# Altium schematic sheet Compressor.SchDoc
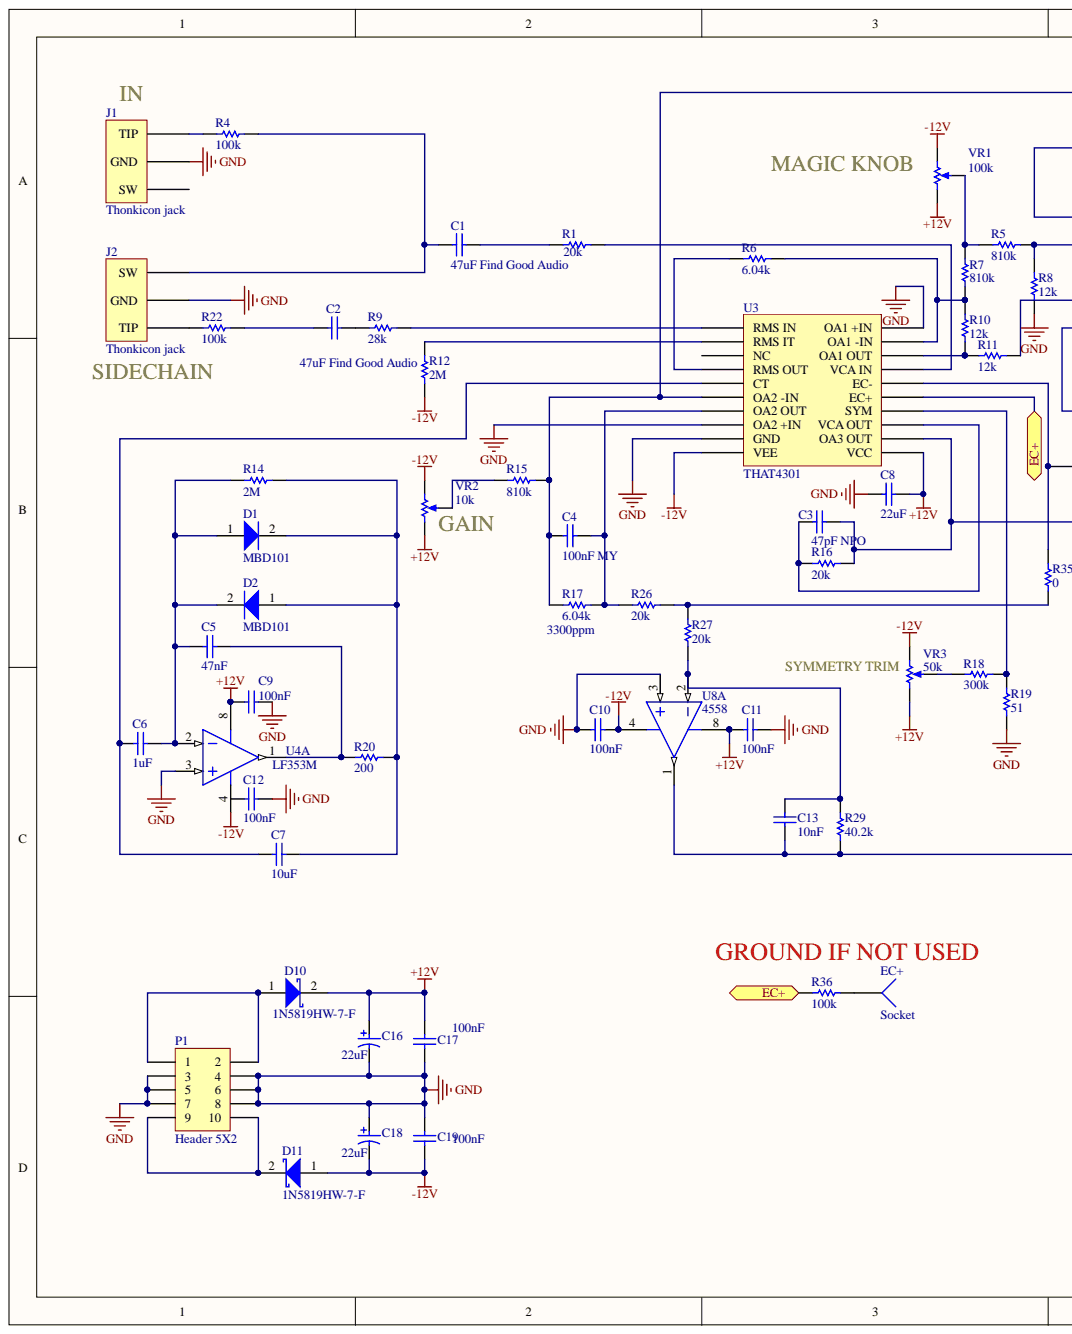
[diagram p0: Compressor.SchDoc, left]
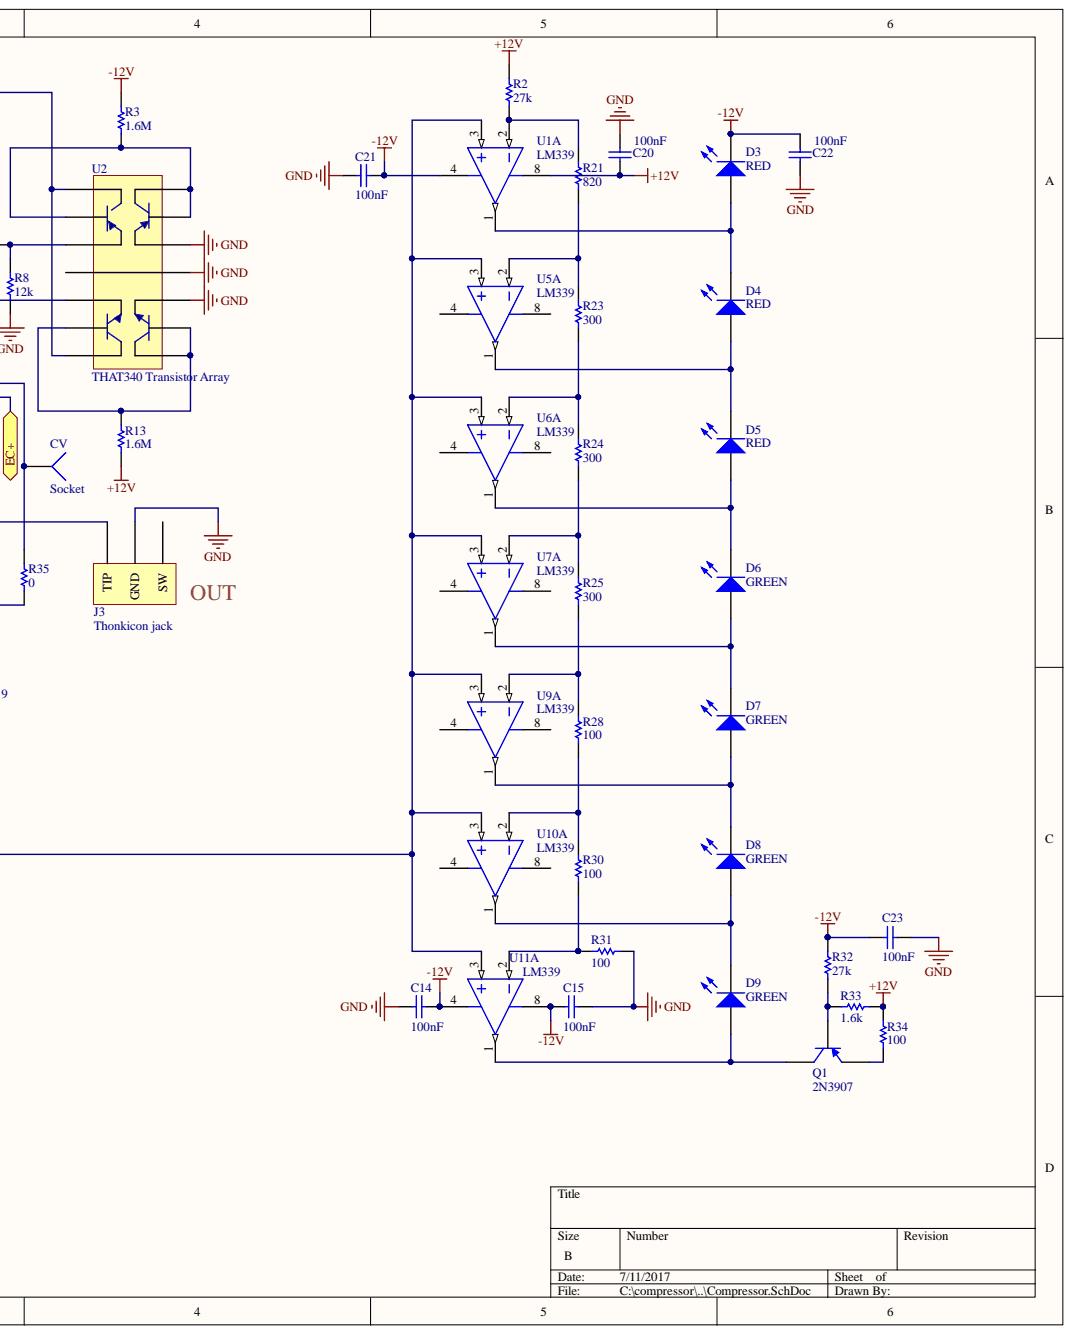
[diagram p1: Compressor.SchDoc, right]

## Parts
C1  47uF Find Good Audio  value 47uF  [CAPC1206(3216)190_N]
C2  47uF Find Good Audio  value 47uF  [CAPC1206(3216)190_N]
C3  47pF NPO  [CAPC1206(3216)190_N]
C4  100nF MY  [CAPC1206(3216)190_N]
C5  47nF  [CAPC1206(3216)190_N]
C6  1uF  [CAPC1206(3216)190_N]
C7  10uF  [CAPC1206(3216)190_N]
C8  22uF  [CAPC1206(3216)190_N]
C9  100nF  [CAPC0603(1608)100_M]
C10  100nF  [CAPC0603(1608)100_M]
C11  100nF  [CAPC0603(1608)100_M]
C12  100nF  [CAPC0603(1608)100_M]
C13  10nF  [CAPC1206(3216)190_N]
C14  100nF  [CAPC0603(1608)100_M]
C15  100nF  [CAPC0603(1608)100_M]
C16  22uF  [NIC-CAPA2-4X5.8_V]
C17  100nF  [CAPC0603(1608)100_M]
C18  22uF  [NIC-CAPA2-4X5.8_V]
C19  100nF  [CAPC0603(1608)100_M]
C20  100nF  [CAPC0603(1608)100_M]
C21  100nF  [CAPC0603(1608)100_M]
C22  100nF  [CAPC0603(1608)100_M]
C23  100nF  [CAPC0603(1608)100_M]
CV  Socket  [PIN1]
D1  MBD101  [ONSC-TO-92-2-182-06]
D2  MBD101  [ONSC-TO-92-2-182-06]
D3  RED  [LED-0]
D4  RED  [LED-0]
D5  RED  [LED-0]
D6  GREEN  [LED-0]
D7  GREEN  [LED-0]
D8  GREEN  [LED-0]
D9  GREEN  [LED-0]
D10  1N5819HW-7-F  [DIOD-SOD-123-2_V]
D11  1N5819HW-7-F  [DIOD-SOD-123-2_V]
EC+  Socket  [PIN1]
J1  Thonkicon jack  [Thonkiconn Pot PJ301M]
J2  Thonkicon jack  [Thonkiconn Pot PJ301M]
J3  Thonkicon jack  [Thonkiconn Pot PJ301M]
P1  Header 5X2  [HDR2X5]
Q1  2N3907  [TO-92A]
R1  20k  [RESC0603(1608)_M]
R2  27k  [RESC0603(1608)_M]
R3  1.6M  [RESC0603(1608)_M]
R4  100k  [RESC0603(1608)_M]
R5  810k  [RESC0603(1608)_M]
R6  6.04k  [RESC0603(1608)_M]
R7  810k  [RESC0603(1608)_M]
R8  12k  [RESC0603(1608)_M]
R9  28k  [RESC0603(1608)_M]
R10  12k  [RESC0603(1608)_M]
R11  12k  [RESC0603(1608)_M]
R12  2M  [RESC0603(1608)_M]
R13  1.6M  [RESC0603(1608)_M]
R14  2M  [RESC0603(1608)_M]
R15  810k  [RESC0603(1608)_M]
R16  20k  [RESC0603(1608)_M]
R17  6.04k  Temp Cpef: 3300ppm  [RESC0603(1608)_M]
R18  300k  [RESC0603(1608)_M]
R19  51  [RESC0603(1608)_M]
R20  200  [RESC0603(1608)_M]
R21  820  [RESC0603(1608)_M]
R22  100k  [RESC0603(1608)_M]
R23  300  [RESC0603(1608)_M]
R24  300  [RESC0603(1608)_M]
R25  300  [RESC0603(1608)_M]
R26  20k  [RESC0603(1608)_M]
R27  20k  [RESC0603(1608)_M]
R28  100  [RESC0603(1608)_M]
R29  40.2k  [RESC0603(1608)_M]
R30  100  [RESC0603(1608)_M]
R31  100  [RESC0603(1608)_M]
R32  27k  [RESC0603(1608)_M]
R33  1.6k  [RESC0603(1608)_M]
R34  100  [RESC0603(1608)_M]
R35  0  [RESC0603(1608)_M]
R36  100k  [RESC0603(1608)_M]
U1  LM339  [D0008A_M]  (part A)
U2  THAT340 Transistor Array
U3  THAT4301
U4  LF353M  [D0008A_M]  (part A)
U5  LM339  [D0008A_M]  (part A)
U6  LM339  [D0008A_M]  (part A)
U7  LM339  [D0008A_M]  (part A)
U8  4558  [D0008A_M]  (part A)
U9  LM339  [D0008A_M]  (part A)
U10  LM339  [D0008A_M]  (part A)
U11  LM339  [D0008A_M]  (part A)
VR1  100k  [SonGueiPot]
VR2  10k  [SonGueiPot]
VR3  50k  [SonGueiPot]

## Ports
EC+

## Nets
+12V: C8.1 C9.1 C11.1 C16.1 C17.1 C20.1 D10.2 R2.1 R13.2 R33.2 R34.2 U1.8 U3.11(VCC) U4.8 U8.8 VR1.3 VR2.3 VR3.3
-12V: C10.1 C14.1 C15.1 C18.2 C19.2 C21.1 C22.2 C23.2 D3.2 D11.1 R3.1 R12.1 R32.2 U1.4 U3.10(VEE) U4.4 U8.4 U11.4 U11.8 VR1.1 VR2.1 VR3.1
GND: C8.2 C9.2 C10.2 C11.2 C12.2 C14.2 C15.2 C16.2 C17.2 C18.1 C19.1 C20.2 C21.2 C22.1 C23.1 J1.1 J2.1 J3.1 P1.3 P1.4 P1.5 P1.6 P1.7 P1.8 R8.1 R19.2 R31.2 U2.3 U2.4 U2.5 U3.8(OA2_+IN) U3.9(GND) U3.20(OA1_+IN) U4.3 U8.3
EC+: R36.2 U3.15(EC+) ; port EC+
NetC1_1: C1.1 R1.2
NetC1_2: C1.2 J2.2 R4.1
NetC2_1: C2.1 R9.1
NetC2_2: C2.2 R22.1
NetC3_1: C3.1 J3.3 R16.1 U3.12(OA3_OUT)
NetC3_2: C3.2 R16.2 U3.13(VCA_OUT)
NetC4_1: C4.1 R17.1 R26.1 U3.7(OA2_OUT)
NetC4_2: C4.2 R15.2 R17.2 U2.8 U2.14 U3.6(OA2_-IN)
NetC5_1: C5.1 R20.2 U4.1
NetC5_2: C5.2 C6.1 D1.1 D2.2 R14.2 U4.2
NetC6_2: C6.2 C7.2 U3.5(CT)
NetC7_1: C7.1 D1.2 D2.1 R14.1 R20.1
NetC13_1: C13.1 R27.1 R29.1 U8.2
NetC13_2: C13.2 R29.2 U1.3 U5.3 U6.3 U7.3 U8.1 U9.3 U10.3 U11.3
NetCV_1: CV.1 R35.1 U3.16(EC-)
NetD3_1: D3.1 D4.2 U1.1
NetD4_1: D4.1 D5.2 U5.1
NetD5_1: D5.1 D6.2 U6.1
NetD6_1: D6.1 D7.2 U7.1
NetD7_1: D7.1 D8.2 U9.1
NetD8_1: D8.1 D9.2 U10.1
NetD9_1: D9.1 Q1.3 U11.1
NetD10_1: D10.1 P1.1 P1.2
NetD11_2: D11.2 P1.9 P1.10
NetEC+_1: EC+.1 R36.1
NetJ1_3: J1.3 R4.2
NetJ2_3: J2.3 R22.2
NetQ1_1: Q1.1 R34.1
NetQ1_2: Q1.2 R32.1 R33.1
NetR1_1: R1.1 U3.17(VCA_IN)
NetR2_2: R2.2 R21.1 U1.2
NetR3_2: R3.2 U2.6 U2.7 U2.9
NetR5_1: R5.1 R8.2 U2.10
NetR5_2: R5.2 R7.2 VR1.2
NetR6_1: R6.1 U3.4(RMS_OUT)
NetR6_2: R6.2 R7.1 R10.2 U3.19(OA1_-IN)
NetR9_2: R9.2 U3.1(RMS_IN)
NetR10_1: R10.1 R11.2 U3.18(OA1_OUT)
NetR11_1: R11.1 U2.12
NetR12_2: R12.2 U3.2(RMS_IT)
NetR13_1: R13.1 U2.1 U2.2 U2.13
NetR15_1: R15.1 VR2.2
NetR18_1: R18.1 R19.1 U3.14(SYM)
NetR18_2: R18.2 VR3.2
NetR21_2: R21.2 R23.1 U5.2
NetR23_2: R23.2 R24.1 U6.2
NetR24_2: R24.2 R25.1 U7.2
NetR25_2: R25.2 R28.1 U9.2
NetR26_2: R26.2 R27.2 R35.2
NetR28_2: R28.2 R30.1 U10.2
NetR30_2: R30.2 R31.1 U11.2

Not connected: C12 1; J1 2; J3 2; U2 11; U3 3(NC); U5 4 8; U6 4 8; U7 4 8; U9 4 8; U10 4 8

## Library data of the parts
C1-C8 C13 = CMP-1037-05002-1: CAP 47uF 10V ±20% 1206 (3216 Metric) Thickness 1.9mm SMD; Case-EIA: 1206; Case-Metric: 3216; Tolerance: Â±20%; Component Kind: Standard; Distributor: Mouser; Library Name: Altium Content Vault; Max Thickness: 1.9 mm; Rated Voltage: 10 V; Technology: SMT
  C3-C8 C13: Value: 47uF
C9-C12 C14 C15 C17 C19-C23 = CMP-1035-00002-1: CAP; Mfr: AVX; Mfr_Pn: MM035C104KCZ2A; Component Kind: Standard; Distributor: Mouser; Library Name: Altium Content Vault
  C9-C12 C14 C15 C20-C23: Distributor: Distributor; Distributor_Pn: Distributor_Pn
  C17 C19: Distributor_Pn: 581-MM035C104KCZ2A
C16 C18 = CMP-0687-00016-1: Aluminum Electrolytic Capacitor, UD Series, Low Impedance, 10 uF, 25 V, + / - 20%, -55 to 105 degC, Chip Type, 4 x 5.8 mm D x L, Pb-Free, Reel; Component Kind: Standard; Distributor: Distributor; Distributor: Mouser; Distributor_Pn: Distributor_Pn; Library Name: Altium Content Vault
D1 D2 = CMP-1055-00719-1: Schottky Barrier Diode, 2-Pin TO-92, Bulk Box; Manufacturer: On Semiconductor; Configuration: Single; Component Kind: Standard; ComponentLink1Description: Manufacturer URL; ComponentLink1URL: http://www.onsemi.com/; ComponentLink2Description: Datasheet; ComponentLink2URL: http://www.onsemi.cn/pub_link/Collateral/MBD101-D.PDF; ComponentLink3Description: Package Specification; ComponentLink3URL: http://www.onsemi.com/pub_link/Collateral/182-06.PDF; DatasheetVersion: Rev. 3; Distributor: Mouser; Library Name: Altium Content Vault; Mounting Technology: Through Hole; PackageDescription: 2-Pin Transistor Outline, Body 4.825 x 3.685 mm, Pitch 2.54 mm; PackageReference: TO-92-2-182-06; PackageVersion: Rev. L, 04/1998; Packing: Bulk Box; PartNumber: MBD101; RoHS: FALSE
D3-D9 = LED0: Typical INFRARED GaAs LED; Component Kind: Standard; Distributor: Mouser; LatestRevisionDate: 08-Jul-2005; LatestRevisionNote: Mech Layer 1 removed.; Library Name: Miscellaneous Devices.IntLib; PackageDescription: LED; 2 Leads; PackageReference: LED-0; Published: 8-Jun-2000; Simulation: LED0; Publisher: Altium Limited
D10 D11 = CMP-0303-00003-1: 1.0 A Surface Mount Schottky Barrier Rectifier, 40 V, -65 to 125 degC, 2-Pin SOD-123 Package, RoHS, Tape and Reel; Mfr: Diodes Inc.; Component Kind: Standard; Distributor: Mouser; Distributor_Pn: 621-1N5819HW-F; Library Name: Altium Content Vault; Mfr_Pn: 1N5819HW-7-F
P1 = Header 5X2: Header, 5-Pin, Dual row; Ditributor_Pn: 855-M20-9980545; Component Kind: Standard; LatestRevisionDate: 17-Jul-2002; LatestRevisionNote: Re-released for DXP Platform.; Library Name: Miscellaneous Connectors.IntLib; Signal Integrity: Connector; Publisher: Altium Limited
Q1 = 2N3906: PNP General Purpose Amplifier; Component Kind: Standard; ComponentLink1Description: Manufacturer Link; ComponentLink1URL: http://www.fairchildsemi.com/; ComponentLink2Description: Datasheet; ComponentLink2URL: http://www.fairchildsemi.com/ds/2N%2F2N3906.pdf; DatasheetVersion: 1997; Distributor: Mouser; LatestRevisionDate: 15-Jan-2003; LatestRevisionNote: PCB Footprint 'BCY-W3/D4.7' replaced; Library Name: Miscellaneous Devices.IntLib; PackageDescription: TO, Flat Index; 3 In-Line, Axial Leads; Body Dia. 4.5mm; Leads 0.51 x 0.48 mm (max); PackageReference: TO-92A; PackageVersion: Sep-1998; Published: 8-Jun-2000; Signal Integrity: 2N3906; Simulation: 2N3906; Publisher: Altium Limited
R1-R5 R7-R36 = CMP-1012-00002-1: Res; Mfr: Susumu; Mfr_Pn: RR0816P-104-D; Case-EIA: 0603; Tolerance: 5 %; Component Kind: Standard; Distributor: Distributor; Distributor: Mouser; Distributor_Pn: Distributor_Pn; Library Name: Altium Content Vault
  R1 R16 R26 R27: Value: 20k
  R2 R32: Value: 27k
  R3 R13: Value: 1.6M
  R4 R22 R36: Value: 100k
  R5 R7 R15: Value: 810k
  R8 R10 R11: Value: 12k
  R9: Value: 28k
  R12 R14: Value: 2M
  R17: Value: 6.04k
  R18: Value: 300k
  R19: Value: 51
  R20: Value: 200
  R21: Value: 820
  R23-R25: Value: 300
  R28 R30 R31 R34: Value: 100
  R29: Value: 40.2k
  R33: Value: 1.6k
  R35: Value: 0
R6 = CMP-1012-00002-1: Res; Mfr: Susumu; Mfr_Pn: RR0816P-104-D; Case-EIA: 0603; temp coef: 3300 ppm; Tolerance: 5 %; Component Kind: Standard; Distributor: Distributor; Distributor: Mouser; Distributor_Pn: Distributor_Pn; Library Name: Altium Content Vault; Value: 6.04k
U1 U4-U11 = CMP-0055-00855-3: Wide Bandwidth Dual JFET Input Operational Amplifier, 8-pin Narrow SOIC; Manufacturer: Texas Instruments; CompType: Amplifiers; PackageTopMarking: NSZXTT*LF353*M; PowerWise: N; Code_IPC: SOIC127P600-8; Code_JEDEC: MS-012-AA; Component Kind: Standard; ComponentLink1Description: Datasheet URL; ComponentLink1URL: http://www.ti.com/general/docs/lit/getliterature.tsp?genericPartNumber=LF353-N&fileType=pdf; Distributor: Mouser; Library Name: Altium Content Vault; PackageDescription: SOIC Narrow, 4.9 x 3.9 x 1.45mm, 8 Lead, 1.27mm Pitch; Package Reference: D0008A; PinCount: 8; RoHS: N
U2 = THAT340: Component Kind: Standard; Distributor: Mouser; Library Name: EurrackParts.SchLib
VR1-VR3 = CMP-0972-00009-1: Trimming Potentiometer, 5K Ohm +/- 10%, -55 to 125 degC, 3-Pin 19.05 x 4.83 x 6.6 mm THD, Transparent Housing, RoHS; Manufacturer: Bourns; PartNumber: 3006P-7-502ZLF; Number of Turns: 15; Resistance (kOhm): 5; Resistance Tolerance: +/- 10%; Rotational Life: 200 Cycles; Component Kind: Standard; ComponentLink1Description: Manufacturer URL; ComponentLink1URL: http://www.bourns.com/; ComponentLink2Description: Datasheet; ComponentLink2URL: http://www.bourns.com/data/global/pdfs/3006.pdf; ComponentLink3Description: Package Specification; ComponentLink3URL: http://www.bourns.com/data/global/pdfs/3006.pdf; DatasheetVersion: Apr-14; Distributor: Mouser; Mounting Technology: Through Hole; PackageDescription: 3-Pin THD, Body 19.05 x 4.83 mm, Height 6.6 mm; PackageReference: 3006P-3; PackageVersion: Apr-14; RoHS: TRUE; Temperature Range: -55 to 125 degC
CV EC+ = Socket: Component Kind: Standard; Description: Socket; Distributor: Mouser; LatestRevisionDate: 17-Jul-2002; LatestRevisionNote: Re-released for DXP Platform.; Library Name: Miscellaneous Connectors.IntLib; Signal Integrity: Connector; Publisher: Altium Limited
J1-J3 = Thonkicon jack: Component Kind: Standard; Distributor: Mouser; Library Name: EurrackParts.SchLib
U3 = THAT4301: Component Kind: Standard; Distributor: Mouser; Library Name: EurrackParts.SchLib
